# Altium schematic sheet Connectors.SchDoc
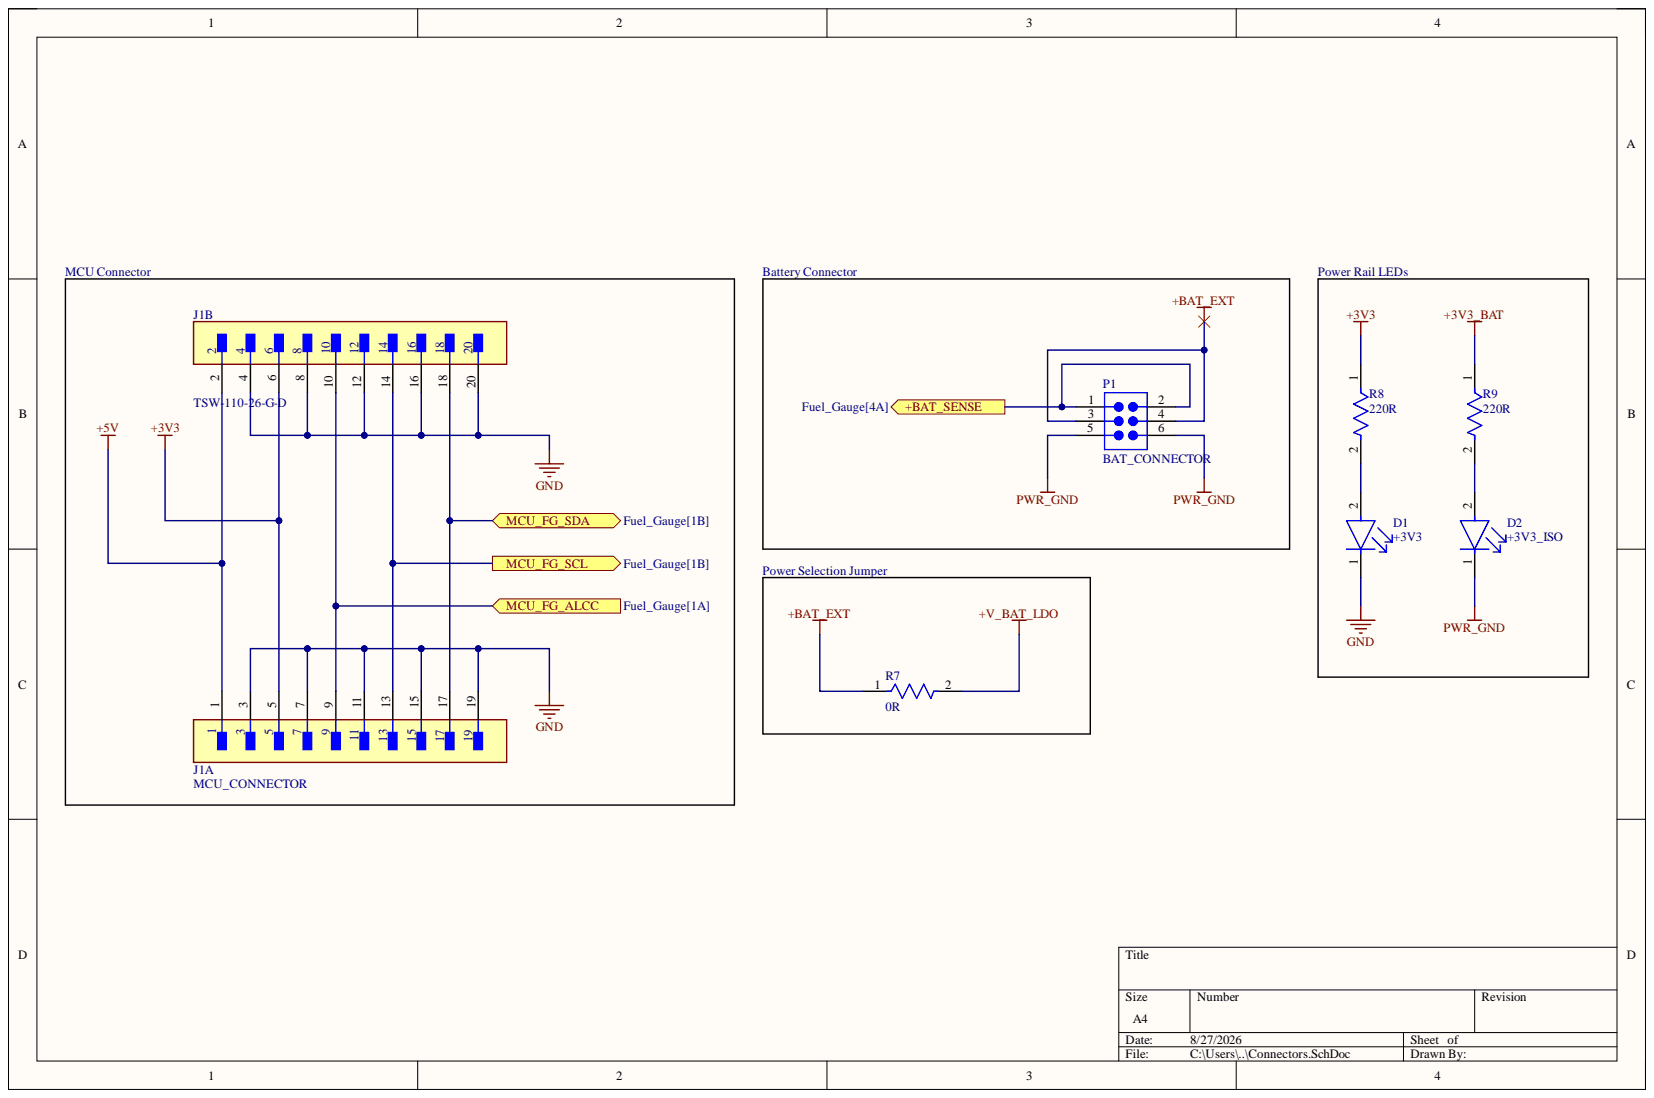
[diagram p0: Connectors.SchDoc]

## Parts
D1  +3V3  [FP-LTST-C170GKT-MFG]
D2  +3V3_ISO  [FP-LTST-C170GKT-MFG]
J1  MCU_CONNECTOR  Manufacturer Part Number: TSW-110-26-G-D  [FP-TSW-110-26-G-D-MFG]  (parts A,B)
P1  BAT_CONNECTOR  [SMTC-TSW-103-08-X-D]
R7  0R  [FP-AF0805-IPC_B]
R8  220R  [FP-RT0805-MFG]
R9  220R  [FP-RT0805-MFG]

## Ports
+BAT_SENSE, MCU_FG_ALCC, MCU_FG_SCL, MCU_FG_SDA

## Nets
+3V3: J1.5 J1.6 R8.1
+3V3_BAT: R9.1
+5V: J1.1 J1.2
+BAT_EXT: P1.3 P1.4 R7.1
+V_BAT_LDO: R7.2
GND: D1.1 J1.3 J1.4 J1.7 J1.8 J1.11 J1.12 J1.15 J1.16 J1.19 J1.20
PWR_GND: D2.1 P1.5 P1.6
+BAT_SENSE: P1.1 P1.2 ; port +BAT_SENSE
MCU_FG_ALCC: J1.9 J1.10 ; port MCU_FG_ALCC
MCU_FG_SCL: J1.13 J1.14 ; port MCU_FG_SCL
MCU_FG_SDA: J1.17 J1.18 ; port MCU_FG_SDA
NetD1_2: D1.2 R8.2
NetD2_2: D2.2 R9.2

## Library data of the parts
D1 D2 = CMP-1674-00002-6: LED GREEN CLEAR SMD; Dominant Wavelength: 569nm; Forward Current: 30mA; Forward Voltage: 2.1V; Lens Color: Colorless; Lens Style: Diffused; Luminous Intensity: 6mcd; Number of Elements: 1; Power Dissipation: 100mW; Reverse Voltage: 5V; Test Current: 10mA; Viewing Angle: 130°; Wavelength: 565nm; Case Code (Imperial): 0805; Case Code (Metric): 2012; Case/Package: 0805; Color: Green; Component Kind: Standard; Depth: 1.25mm; Height: 1.1mm; Illumination Color: Green; Lead Free: Lead Free; Length: 2mm; Lens Transparency: Clear; Library Name: Altium Content Vault; Max Operating Temperature: 85°C; Min Operating Temperature: -55°C; Mount: Surface Mount; Number of LEDs: 1; Number of Pins: 2; Package Quantity: 1; Packaging: Tape and Reel; Power Rating: 100mW; Radiation Hardening: No; Voltage: 5V; Width: 1.25mm
J1 = CMP-02766-000032-1: CONN HEADER VERT 20POS 2.54MM; Manufacturer: Samtec; Conn Gender: Male; Conn Orientation: Vertical; Number Of Contacts: 20; Automotive: No; Category: Conn; Component Kind: Standard; ComponentLink2Description: Datasheet; ComponentLink2URL: http://suddendocs.samtec.com/prints/tsw-xxx-xx-xxx-x-xx-xxx-mkt.pdf; Contact Material: Gold,Nickel; Device Class L1: Connectors; Device Class L2: Headers and Wire Housings; Device Class L3: unset; Digikey Description: CONN HEADER VERT 20POS 2.54MM; Footprint Url: http://suddendocs.samtec.com/prints/tsw-xxx-xx-x-x-xx-xxx-footprint.pdf; Height: 8.71mm; Lead Free: Yes; Library Name: Altium Content Vault; Number Of Rows: 2; Octopart: https://octopart.com/tsw-110-26-g-d-samtec-274552; Package: TSW-110-26-G-D; Pitch: 2.54mm; RoHS: TRUE; Temperature: -55°C to +125°C; Temperature Range High: +125°C; Temperature Range Low: -55°C
P1 = CMP-1024-00338-1: 0.025" SQ Post Header, Through-hole, Vertical, -55 to 125 degC, 2.54 mm Pitch, 6-Pin, Male, RoHS; Manufacturer: Samtec; PartNumber: TSW-103-08-G-D; Pitch: 2.54 mm; Component Kind: Standard; ComponentLink1Description: Manufacturer URL; ComponentLink1URL: http://www.samtec.com; ComponentLink2Description: Datasheet; ComponentLink2URL: http://www.samtec.com/documents/webfiles/pdf/TSW_TH.PDF; ComponentLink3Description: Package Specification; ComponentLink3URL: http://www.samtec.com/documents/webfiles/cpdf/TSW-XXX-XX-XXX-X-XX-XXX-MKT.pdf; DatasheetVersion: F-214; Library Name: Altium Content Vault; Mounting Technology: Through-hole; Orientation: Vertical; PackageDescription: .025[0.64] SQ. Post Terminal Strip Assembly; PackageReference: TSW-103-08-X-D; PackageVersion: DM, 8/1988; RoHS: True; Temperature Range: -55 to 125 degC
R7 = CMP-03412-047152-1: Anti-Sulfurated Chip Resistor Automotive Grade 0Ω Jumper 0.125W 0805; Max Power Dissipation: 125mW; Case Code (Imperial): 0805; Case Code (Metric): 2012; Case/Package: 0805; Component Kind: Standard; Features: Moisture Resistant; Height: 0.024inch; Length: 0.079inch; Library Name: Altium Content Vault; Max Operating Temperature: 155°C; Min Operating Temperature: -55°C; Number of Terminations: 2; Package Quantity: 5000; Packaging: Tape and Reel; Resistance: 0R; Resistor Type: Thick Film; RoHS Compliant: Yes; Series: AF; Termination: SMD/SMT; Weight: 0.000194oz; Width: 1.25mm
R8 R9 = CMP-03412-035594-1: RES SMD 220 OHM 1% 1/8W 0805; Manufacturer: Yageo; Manufacturer Part Number: RT0805FRE07220RL; Power Rating: 0.125W; Resistance: 220Ω; Temperature Coefficient: 50 ppm/°C; Tolerance: 0.0001; Value: 220Ω; Voltage Rating: 150V; Automotive: No; Category: Res; Component Kind: Standard; ComponentLink2Description: Datasheet; ComponentLink2URL: http://www.yageo.com/documents/recent/PYu-RT_1-to-0.01_RoHS_L_9.pdf; Composition: Thin Film; Device Class L1: Passive Components; Device Class L2: Resistors; Device Class L3: Chip SMD Resistors; Digikey Description: RES SMD 220 OHM 1% 1/8W 0805; Footprint Url: http://www.yageo.com/exep/pages/download/literatures/PYu-R_Mount_10.pdf; Height: 0.6mm; Ipc Land Pattern Name: RESC200125X50; Lead Free: Yes; Library Name: Altium Content Vault; Mouser Description: Res Thin Film 0805 220 Ohm 1% 1/8W ±50ppm/°C SMD Paper T/R; Octopart: https://octopart.com/rt0805fre07220rl-yageo-39797223; Package: RT0805; RoHS: TRUE; Series: RT; Temperature: -55°C to +155°C; Temperature Range High: +155°C; Temperature Range Low: -55°C
